# Altium schematic sheet Relays.SchDoc
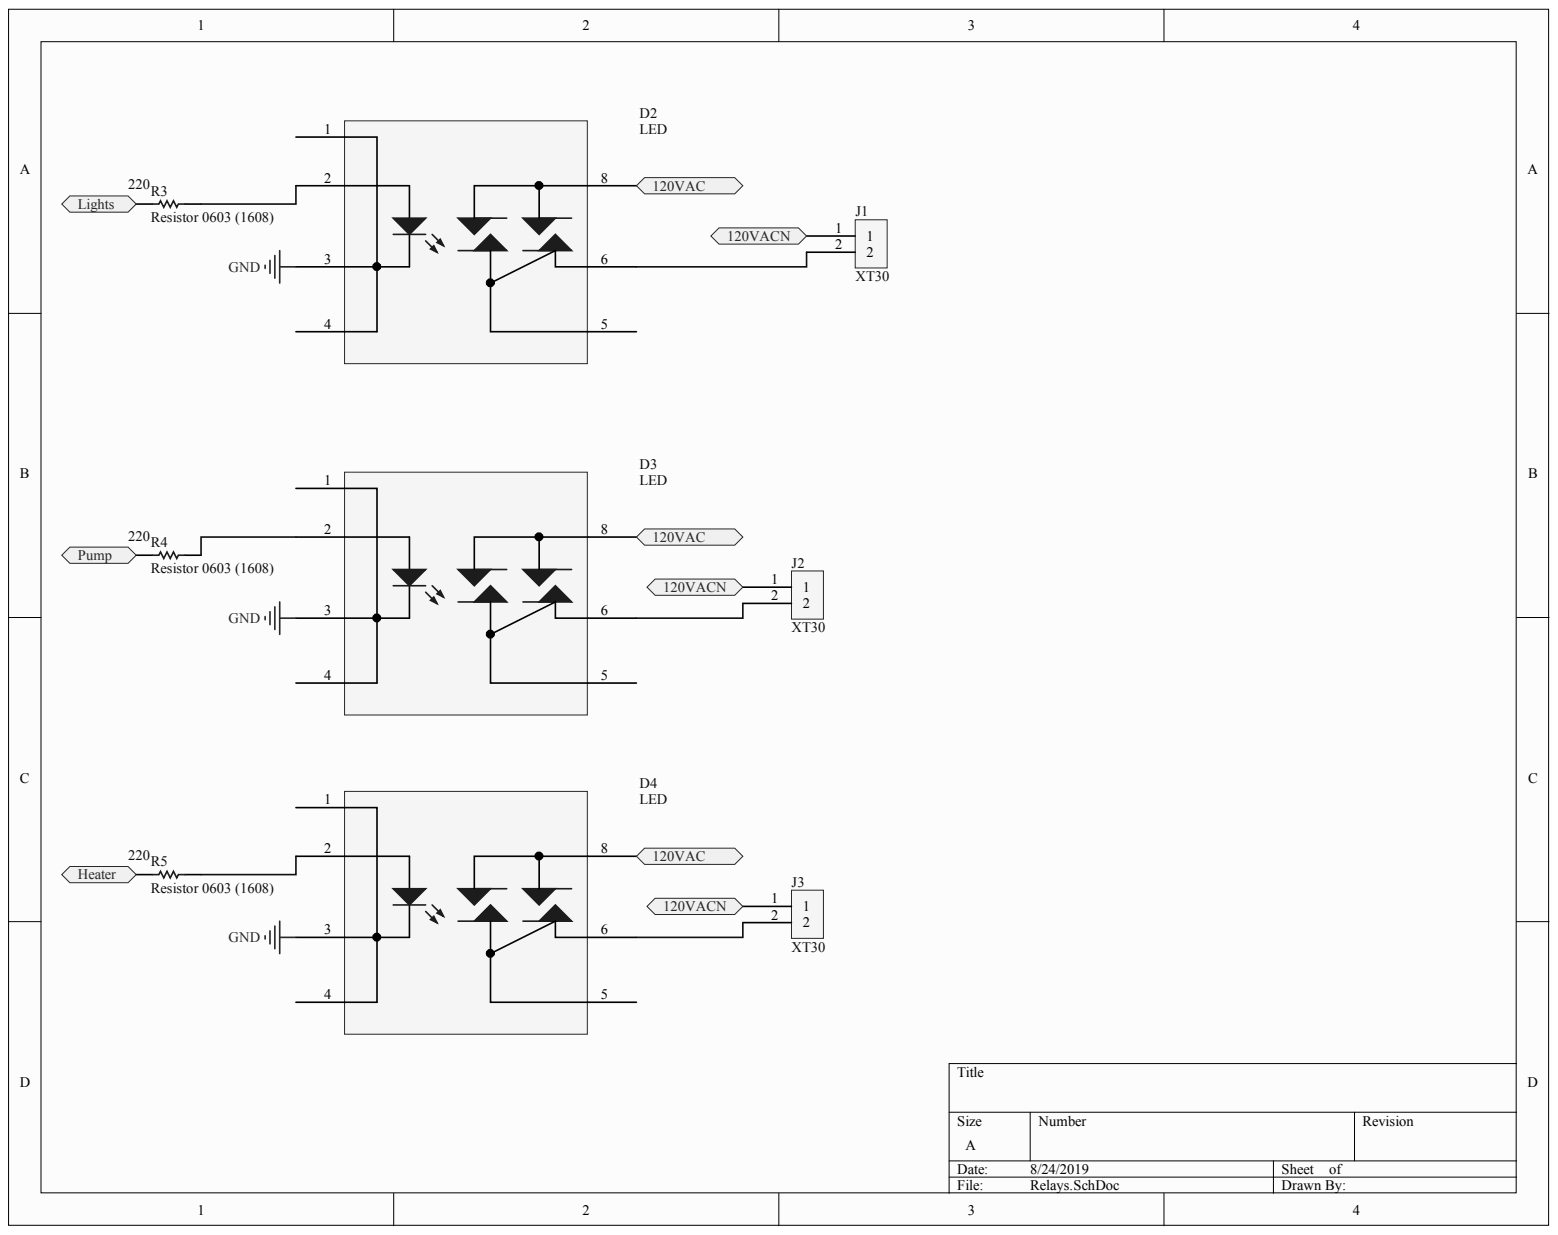
[diagram p0: Relays.SchDoc]

## Parts
D2  LED  [MCHP-PDIP-P8]
D3  LED  [MCHP-PDIP-P8]
D4  LED  [MCHP-PDIP-P8]
J1  XT30  [PCB-8h6goupi0sbkduz6cbnu-1]
J2  XT30  [PCB-8h6goupi0sbkduz6cbnu-1]
J3  XT30  [PCB-8h6goupi0sbkduz6cbnu-1]
R3  Resistor 0603 (1608)  [PCB-8xtmhdk62wywm3ayihyv-1]
R4  Resistor 0603 (1608)  [PCB-8xtmhdk62wywm3ayihyv-1]
R5  Resistor 0603 (1608)  [PCB-8xtmhdk62wywm3ayihyv-1]

## Ports
120VAC, 120VACN, HEATER, LIGHTS, PUMP

## Nets
GND: D2.3 D3.3 D4.3
120VAC: D2.8 D3.8 D4.8 ; port 120VAC
120VACN: J1.1 J2.1 J3.1 ; port 120VACN
NetD2_2: D2.2 R3.2
NetD2_6: D2.6 J1.2
NetD3_2: D3.2 R4.2
NetD3_6: D3.6 J2.2
NetD4_2: D4.2 R5.2
NetD4_6: D4.6 J3.2
NetR3_1: R3.1 ; port LIGHTS
NetR4_1: R4.1 ; port PUMP
NetR5_1: R5.1 ; port HEATER

Not connected: D2 1 4 5; D3 1 4 5; D4 1 4 5

## Library data of the parts
D2-D4 = CMP-87884edea0ab584f-2: AH-H Series SPST 1.2 A 600 V Surface Mount Random State Relay - DIP-8; Manufacturer Part Number: AQH3223AZ; Lead-Free Status: Lead Free; Component Kind: Standard; Library Name: CommunityVault; Octopart URL: http://octopart.com/aqh3223az-panasonic-6029893; RoHS: Compliant
J1-J3 = CMP-nlx8yci0umf6n9sk0ty3-5: Very similar to the XT60 models, but smaller and made for 30A; Component Kind: Standard; CustomComponentID: nlx8yci0umf6n9sk0ty3; Homepage URL: https://www.amazon.com/ProgressiveRC-AC-XT30-XT30-Connectors-pairs/dp/B011WDZGM0; Library Name: FavoritesLibrary
R3-R5 = CMP-8d0d5kw4b9o869c0if8y-1: Generic Resistor pad 0603 Imperial and 1608 Metric; Resistance: 220; Case/Package: 0603 (1608); Component Kind: Standard; CustomComponentID: 8d0d5kw4b9o869c0if8y; Library Name: CommunityVault
